# Altium schematic sheet ADCS.SchDoc
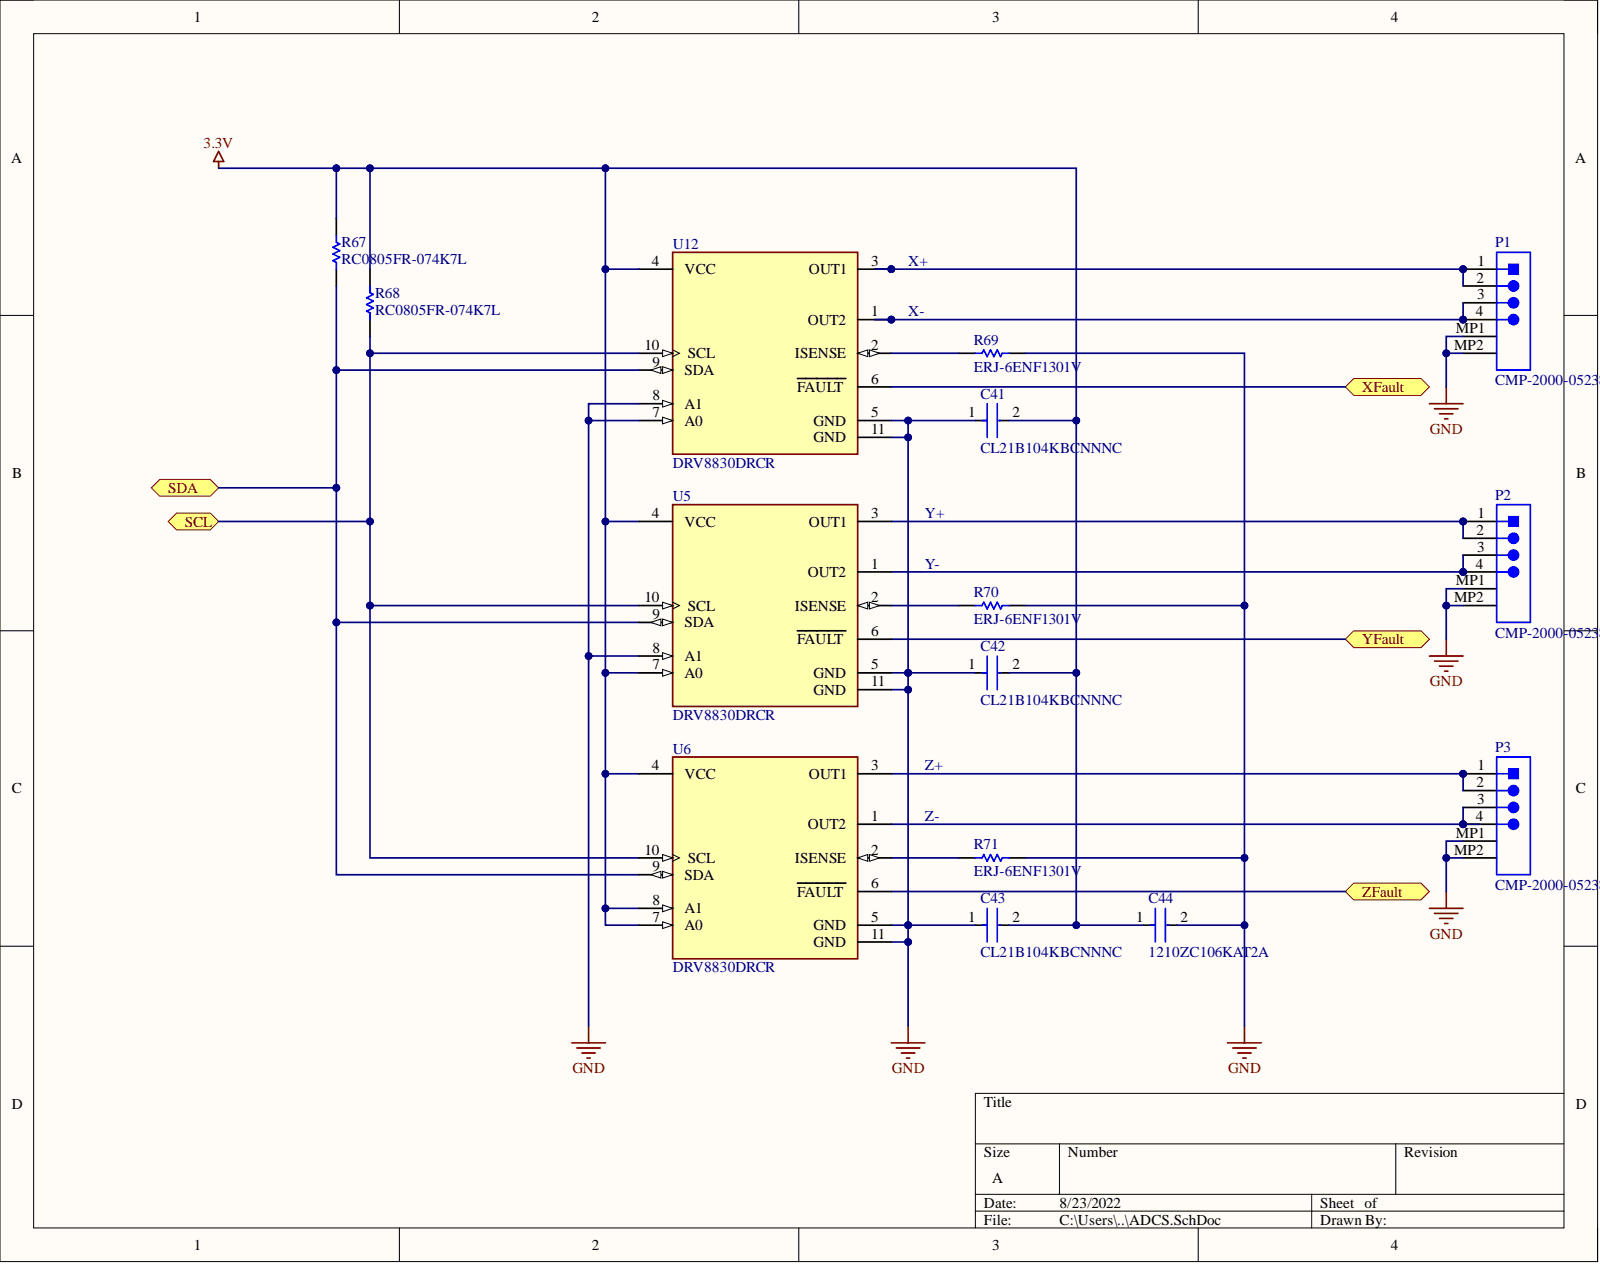
[diagram p0: ADCS.SchDoc]

## Parts
C41  CL21B104KBCNNNC  [FP-CL21-IPC_C]
C42  CL21B104KBCNNNC  [FP-CL21-IPC_C]
C43  CL21B104KBCNNNC  [FP-CL21-IPC_C]
C44  1210ZC106KAT2A  [FP-1210-L_3_3_0_4-W_2_5_0-IPC_A]
P1  CMP-2000-05238-1  [MOLEX-53261-0471_V]  (value not drawn)
P2  CMP-2000-05238-1  [MOLEX-53261-0471_V]  (value not drawn)
P3  CMP-2000-05238-1  [MOLEX-53261-0471_V]  (value not drawn)
R67  RC0805FR-074K7L  [YAGO-RC0805_M]
R68  RC0805FR-074K7L  [YAGO-RC0805_M]
R69  ERJ-6ENF1301V  [RESC2013X70X40NL20T20]
R70  ERJ-6ENF1301V  [RESC2013X70X40NL20T20]
R71  ERJ-6ENF1301V  [RESC2013X70X40NL20T20]
U5  DRV8830DRCR  [FP-DRC0010J-MFG]
U6  DRV8830DRCR  [FP-DRC0010J-MFG]
U12  DRV8830DRCR  [FP-DRC0010J-MFG]

## Ports
SCL, SDA, XFault, YFault, ZFault

## Nets
3.3V: C41.2 C42.2 C43.2 C44.1 R67.2 R68.2 U5.4(VCC) U5.7(A0) U6.4(VCC) U6.7(A0) U6.8(A1) U12.4(VCC)
GND: C41.1 C42.1 C43.1 C44.2 P1.MP1 P1.MP2 P2.MP1 P2.MP2 P3.MP1 P3.MP2 R69.2 R70.2 R71.2 U5.5(GND) U5.8(A1) U5.11(GND) U6.5(GND) U6.11(GND) U12.5(GND) U12.7(A0) U12.8(A1) U12.11(GND)
SCL: R68.1 U5.10(SCL) U6.10(SCL) U12.10(SCL) ; port SCL
SDA: R67.1 U5.9(SDA) U6.9(SDA) U12.9(SDA) ; port SDA
XFault: U12.6(FAULT) ; port XFault
YFault: U5.6(FAULT) ; port YFault
ZFault: U6.6(FAULT) ; port ZFault
NetP1_1: P1.1 P1.2 U12.3(OUT1)
NetP1_3: P1.3 P1.4 U12.1(OUT2)
NetP2_1: P2.1 P2.2 U5.3(OUT1)
NetP2_3: P2.3 P2.4 U5.1(OUT2)
NetP3_1: P3.1 P3.2 U6.3(OUT1)
NetP3_3: P3.3 P3.4 U6.1(OUT2)
NetR69_1: R69.1 U12.2(ISENSE)
NetR70_1: R70.1 U5.2(ISENSE)
NetR71_1: R71.1 U6.2(ISENSE)

## Library data of the parts
C41-C43 = CMP-2000-05017-2: CL21 Series 0805 100nF 50V ±10% Tolerance X7R Multilayer Ceramic Chip Capacitor; Capacitance: 100nF; Case Code (Imperial): 0805; Case Code (Metric): 2012; Case/Package: 0805; Component Kind: Standard; Contact Plating: Tin; Depth: 1.25mm; Dielectric: X7R; Height: 0.85mm; Lead Free: Lead Free; Length: 2mm; Library Name: Altium Content Vault; Material: Ceramic; Max Operating Temperature: 125°C; Min Operating Temperature: -55°C; Mount: Surface Mount; Packaging: Tape and Reel; Radiation Hardening: No; Thickness: 0.037inch; Tolerance: 10%; Voltage: 50V; Voltage Rating: 50V; Voltage Rating (DC): 50V; Width: 0.049inch
C44 = CMP-1038-02198-3: CAP CER 10UF 10V X7R 1210; Dissipation Factor: 5%; Tolerance: 10%; Capacitance: 10uF; Case Code (Imperial): 1210; Case Code (Metric): 3225; Case/Package: 1210; Component Kind: Standard; Construction: Flat; Depth: 2.5mm; Dielectric: X7R; Height: 2.79mm; Lead Free: Lead Free; Length: 3.2mm; Library Name: Altium Content Vault; Max Operating Temperature: 125°C; Min Operating Temperature: -55°C; Mount: Surface Mount; Package Quantity: 1; Packaging: Tape and Reel; Radiation Hardening: No; REACH SVHC: No SVHC; RoHS Compliant: Yes; Series: 1210; Termination: SMD/SMT; Thickness: 0.11inch; Voltage: 10V; Voltage Rating: 10V; Voltage Rating (DC): 10V; Width: 0.098inch
P1-P3 = CMP-2000-05238-1: Male Header, Pitch 1.25 mm, 1 x 4 Position, Height 3.4 mm, -40 to 85 degC, RoHS, Tape and Reel; MPN: 0533984004; Component Kind: Standard; Library Name: mainboard_1.SCHLIB; Supplier 1: Digi-Key
R67 R68 = CMP-1568-00001-1: General Puprose Chip Resistor, 4700 Ohm +/- 1%, 0.125 W, -55 to 155 degC, 2-Pin SMD, RoHS, Tape and Reel; Power: 0.125 W; Tolerance: +/- 1%; Component Kind: Standard; ComponentLink1Description: Manufacturer URL; ComponentLink1URL: http://www.yageo.com/NewPortal/_en/index.jsp; ComponentLink2Description: Datasheet; ComponentLink2URL: http://www.yageo.com/documents/recent/PYu-RC_Group_51_RoHS_L-3.pdf; DatasheetVersion: Rev. 3; Library Name: Altium Content Vault; Mounting Technology: Surface Mount; PackageDescription: 2-Pin Surface Mount Device, Body 2 x 1.25 mm; Packing: Tape and Reel; PartNumber: RC0805FR-074K7L; Resistance: 4700 Ohm; RoHS: TRUE; Temperature Max: 155 degC; Temperature Min: -55 degC
R69-R71 = CMP-2001-04415-1: Manufacturer: Panasonic; Component Kind: Standard; Library Name: Altium Content Vault; Part Number: ERJ-6ENF1301V
U5 U6 U12 = CMP-04915-000001-1: IC MTRDRV BIPLR 2.75-6.8V 10VSON; Manufacturer: Texas Instruments; Max Junction Temp: +150°C; Max Supply Voltage: 6.8V; Min Supply Voltage: 2.75V; Motor Type: Brushed; Nominal Supply Current: 1.4mA; Number Of Outputs: 1; Output Current: 1A; Standoff Height: 0mm; Switching Frequency: 44.5kHz; Automotive: No; Category: IC; Component Kind: Standard; ComponentLink2Description: Datasheet; ComponentLink2URL: http://www.ti.com/lit/ds/symlink/drv8830.pdf; Device Class L1: Integrated Circuits (ICs); Device Class L2: Power Management ICs; Device Class L3: Motor Drivers; Digikey Description: IC MTRDRV BIPLR 2.75-6.8V 10VSON; Height: 1mm; Lead Free: Yes; Library Name: mainboard_1.SCHLIB; Mouser Description: 1A Low Voltage Brushed DC Motor Driver with Speed Regulation (I2C Ctrl) 10-VSON -40 to 85; MPN: DRV8830DRCR; Octopart: https://octopart.com/drv8830drcr-texas+instruments-21221812; Package: DRC0010J; RoHS: TRUE; Temperature: -40°C to +85°C; Temperature Range High: +85°C; Temperature Range Low: -40°C
